# Altium schematic sheet PS_GETH_PHY.SchDoc
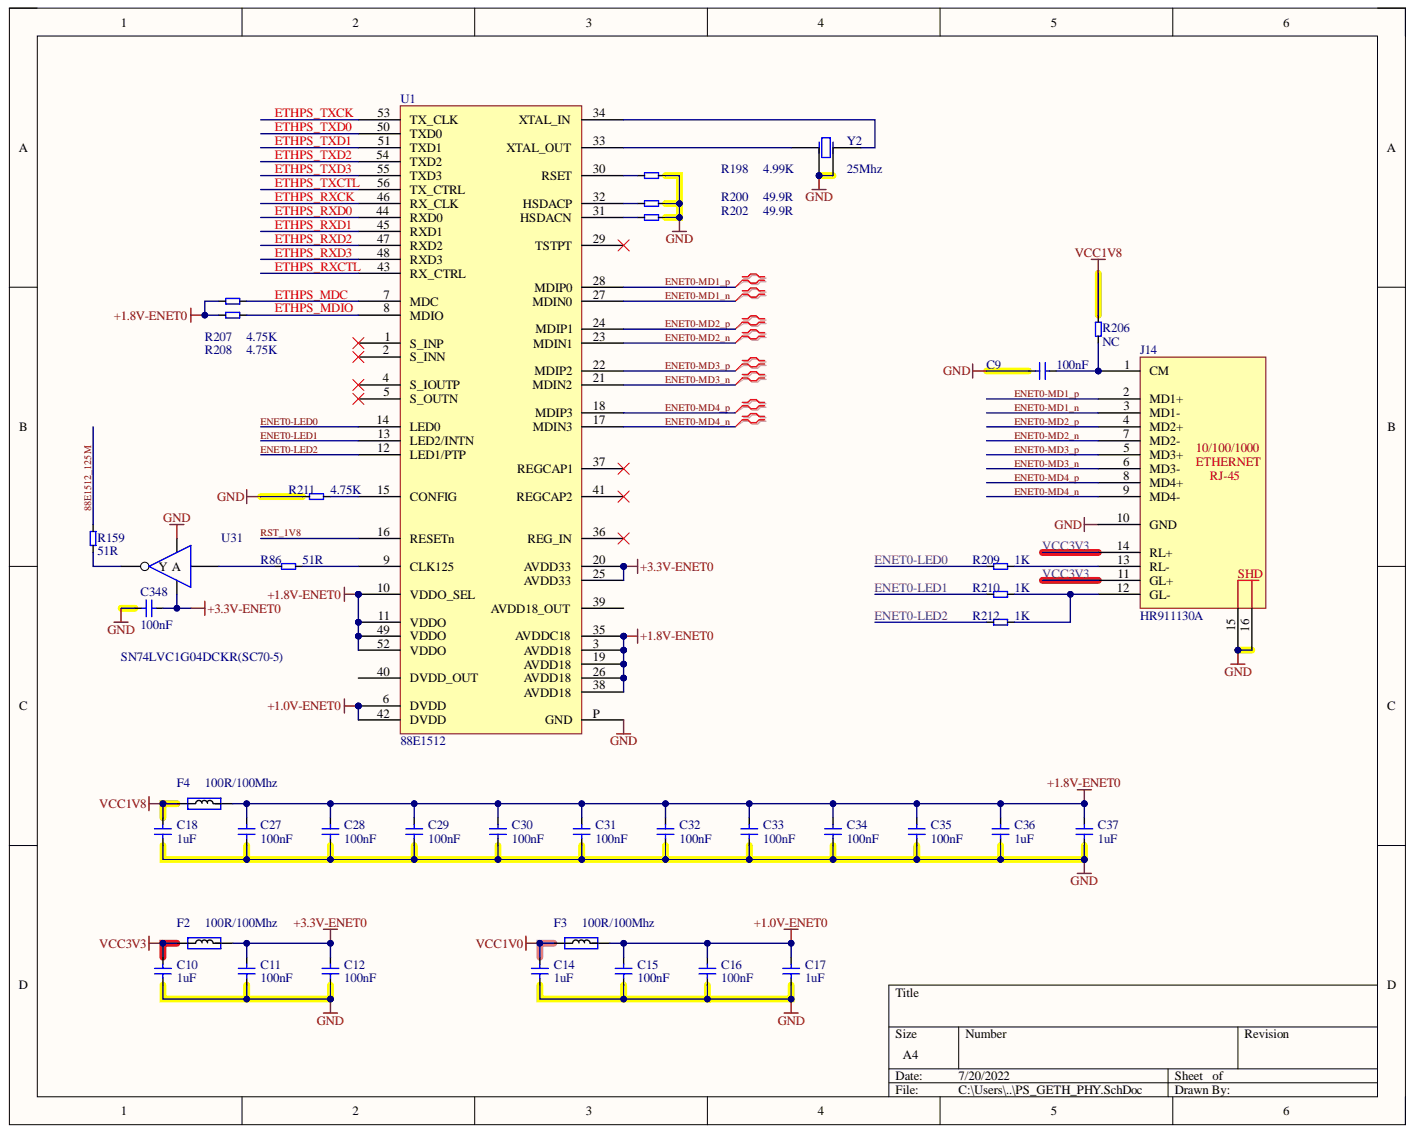
[diagram p0: PS_GETH_PHY.SchDoc]

## Parts
C9  100nF  [C0201]
C10  1uF  [C0402]
C11  100nF  [C0201]
C12  100nF  [C0201]
C14  1uF  [C0402]
C15  100nF  [C0201]
C16  100nF  [C0201]
C17  1uF  [C0402]
C18  1uF  [C0402]
C27  100nF  [C0201]
C28  100nF  [C0201]
C29  100nF  [C0201]
C30  100nF  [C0201]
C31  100nF  [C0201]
C32  100nF  [C0201]
C33  100nF  [C0201]
C34  100nF  [C0201]
C35  100nF  [C0201]
C36  1uF  [C0402]
C37  1uF  [C0402]
C348  100nF  [C0201]
F2  100R/100Mhz  [L0402]
F3  100R/100Mhz  [L0402]
F4  100R/100Mhz  [L0402]
J14  HR911130A  [RJ45_2021]
R86  51R  [R0201]
R159  51R  [R0201]
R198  4.99K  [R0201]
R200  49.9R  [R0201]
R202  49.9R  [R0201]
R206  NC  [R0201]
R207  4.75K  [R0201]
R208  4.75K  [R0201]
R209  1K  [R0201]
R210  1K  [R0201]
R211  4.75K  [R0201]
R212  1K  [R0201]
U1  88E1512  [QFN-56_8x8x05P]
U31  SN74LVC1G04DCKR(SC70-5)  [SC-70-5]
Y2  25Mhz  [osc_3225]

## Nets
+1.0V-ENET0: C15.1 C16.1 C17.1 F3.2 U1.6(DVDD) U1.42(DVDD)
+1.8V-ENET0: C27.1 C28.1 C29.1 C30.1 C31.1 C32.1 C33.1 C34.1 C35.1 C36.1 C37.1 F4.2 R207.2 R208.2 U1.3(AVDD18) U1.10(VDDO_SEL) U1.11(VDDO) U1.19(AVDD18) U1.26(AVDD18) U1.35(AVDDC18) U1.38(AVDD18) U1.49(VDDO) U1.52(VDDO)
+3.3V-ENET0: C11.1 C12.1 C348.2 F2.2 U1.20(AVDD33) U1.25(AVDD33) U31.5(A)
GND: C9.1 C10.2 C11.2 C12.2 C14.2 C15.2 C16.2 C17.2 C18.2 C27.2 C28.2 C29.2 C30.2 C31.2 C32.2 C33.2 C34.2 C35.2 C36.2 C37.2 C348.1 J14.10(GND) J14.15 J14.16 R198.1 R200.1 R202.1 R211.2 U1.P(GND) U31.3 Y2.2 Y2.4
VCC1V0: C14.1 F3.1
VCC1V8: C18.1 F4.1 R206.2
88E1512_125M: R159.1
ENET0-LED0: R209.1 U1.14(LED0)
ENET0-LED1: R210.1 U1.13(LED2/INTN)
ENET0-LED2: R212.1 U1.12(LED1/PTP)
ENET0-MD1_n: J14.3(MD1-) U1.27(MDIN0)
ENET0-MD1_p: J14.2(MD1+) U1.28(MDIP0)
ENET0-MD2_n: J14.7(MD2-) U1.23(MDIN1)
ENET0-MD2_p: J14.4(MD2+) U1.24(MDIP1)
ENET0-MD3_n: J14.6(MD3-) U1.21(MDIN2)
ENET0-MD3_p: J14.5(MD3+) U1.22(MDIP2)
ENET0-MD4_n: J14.9(MD4-) U1.17(MDIN3)
ENET0-MD4_p: J14.8(MD4+) U1.18(MDIP3)
ETHPS_MDC: R207.1 U1.7(MDC)
ETHPS_MDIO: R208.1 U1.8(MDIO)
ETHPS_RXCK: U1.46(RX_CLK)
ETHPS_RXCTL: U1.43(RX_CTRL)
ETHPS_RXD0: U1.44(RXD0)
ETHPS_RXD1: U1.45(RXD1)
ETHPS_RXD2: U1.47(RXD2)
ETHPS_RXD3: U1.48(RXD3)
ETHPS_TXCK: U1.53(TX_CLK)
ETHPS_TXCTL: U1.56(TX_CTRL)
ETHPS_TXD0: U1.50(TXD0)
ETHPS_TXD1: U1.51(TXD1)
ETHPS_TXD2: U1.54(TXD2)
ETHPS_TXD3: U1.55(TXD3)
RST_1V8: U1.16(RESETn)
VCC3V3: C10.1 F2.1 J14.11(GL+) J14.14(RL+)
NetC9_2: C9.2 J14.1(CM) R206.1
NetJ14_12: J14.12(GL-) R210.2 R212.2
NetJ14_13: J14.13(RL-) R209.2
NetR86_1: R86.1 U1.9(CLK125)
NetR86_2: R86.2 U31.2
NetR159_2: R159.2 U31.4(Y)
NetR198_2: R198.2 U1.30(RSET)
NetR200_2: R200.2 U1.32(HSDACP)
NetR202_2: R202.2 U1.31(HSDACN)
NetR211_1: R211.1 U1.15(CONFIG)
NetU1_33: U1.33(XTAL_OUT) Y2.1
NetU1_34: U1.34(XTAL_IN) Y2.3

Not connected: U1 1(S_INP) 2(S_INN) 4(S_IOUTP) 5(S_OUTN) 29(TSTPT) 36(REG_IN) 37(REGCAP1) 39(AVDD18_OUT) 40(DVDD_OUT) 41(REGCAP2)

## Library data of the parts
C9-C12 C14-C18 C27-C37 C348 = C: Capacitor; Component Kind: Standard; Library Name: 电容-SCH.LIB
F2-F4 = Ferrite: Manufacturer: TDK Corporation; Manufacturer Part Number 1: MPZ2012S601AT000; Part Description: FERRITE BEAD 600 OHM 0805 1LN; Current Rating: 2A; DC Resistance (DCR): 100 mOhm Max; Filter Type: Differential Mode - Single; Impedance @ Frequency: 600 Ohm @ 100MHz; Value: 600R, 2A; Category: Filters; Component Kind: Standard; Height (Max): 0.041" (1.05mm); Mounting Type: Surface Mount; Package / Case: 0805 (2012 Metric); Packaging: Tape & Reel (TR); RoHS: RoHS Compliant; Size / Dimension: 0.079" L x 0.049" W (2.00mm x 1.25mm); Supplier 1: Digi-Key; Supplier Part Number 1: 445-2206-2-ND; Library Name: LimeMicroAltiumLib_schLib.SchLib
J14 = 1840750-7: HR911130A 千兆以太网接口; Component Kind: Standard; Library Name: PCB_Project.SCHLIB
R86 R159 R198 R200 R202 R206-R212 = RES2: Component Kind: Standard; Library Name: 电阻-SCH.LIB
U31 = SN74LVC1G04DCKR: Component Kind: Standard
Y2 = SMD-3225_4P  25MHZ 12PF 10PPM: 无源4P贴片晶体3225; Component Kind: Standard; ComponentLink1Description: 供应商链接; ComponentLink1URL: http://www.szlcsc.com/product/details_9526.html; Package: SMD-3225_4P; Supplier: LC; SuppliersPartNumber: C9006; Library Name: ATE公司集成库.IntLib
U1 = 88E1512: Component Kind: Standard; Library Name: N310.SCHLIB
